# Altium schematic sheet Sheet3.SchDoc(Sheet3)
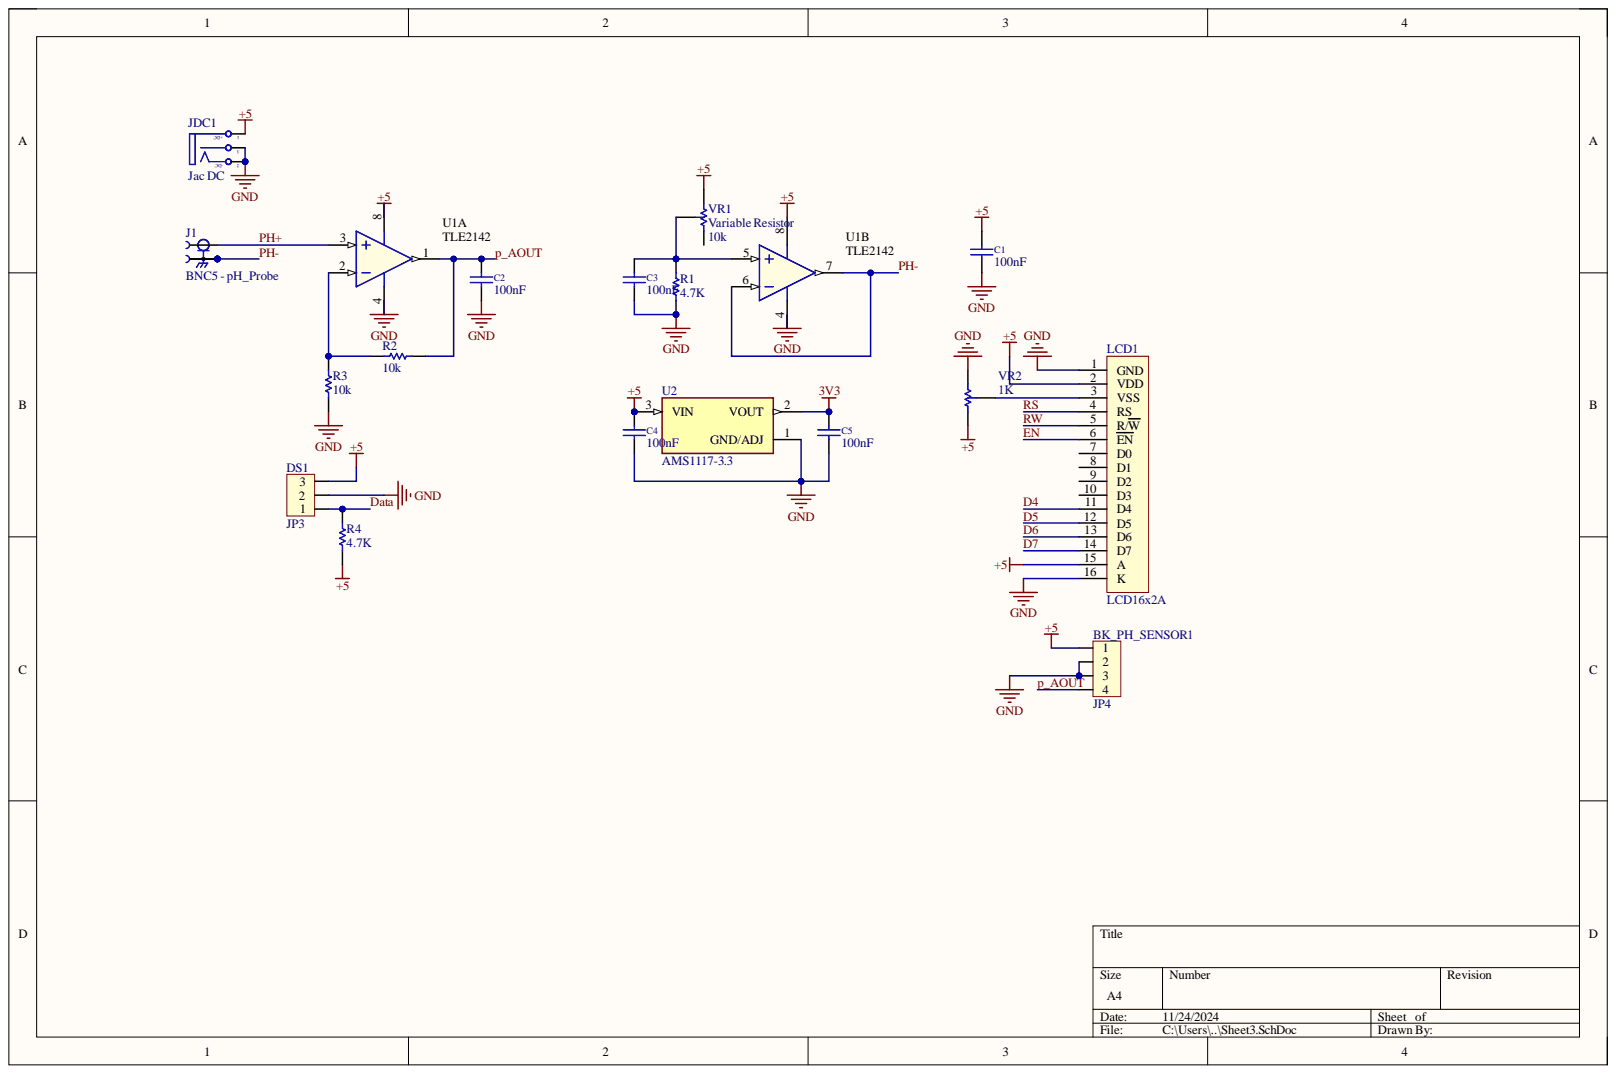
[diagram p0: Sheet3.SchDoc]

## Parts
BK_PH_SENSOR1  JP4  [CON4]
C1  100nF  [C2012-0805]
C2  100nF  [C2012-0805]
C3  100nF  [C2012-0805]
C4  100nF  [C2012-0805]
C5  100nF  [C2012-0805]
DS1  JP3  [CON3]
J1  BNC5 - pH_Probe  [SAMTEC_BNC5-J-P-GN-RA-BH2D]
JDC1  Jac DC  [DC-IN]
LCD1  LCD16x2A  [LCD16X2]
R1  value 4.7K  [R-0805]  (hidden comment: RESISTOR)
R2  value 10k  [R-0805]  (hidden comment: RESISTOR)
R3  value 10k  [R-0805]  (hidden comment: RESISTOR)
R4  value 4.7K  [R-0805]  (hidden comment: RESISTOR)
U1  TLE2142  [SOIC8]  (parts A,B)
U2  AMS1117-3.3  [SOT229P700X180-4N]
VR1  Variable Resistor  value 10k  [VRes-R]
VR2  value 1K  [VRes-C]

## Nets
3V3: C5.2 U2.2
+5: BK_PH_SENSOR1.1 C1.2 C4.2 DS1.3 JDC1.1 LCD1.2(VDD) LCD1.15(A) R4.1 U1.8 U2.3 VR1.1 VR2.1
GND: BK_PH_SENSOR1.2 BK_PH_SENSOR1.3 C1.1 C2.1 C3.1 C4.1 C5.1 DS1.2 JDC1.2 JDC1.3 LCD1.1(GND) LCD1.16(K) R1.1 R3.1 U1.4 U2.1 VR2.3
D4: LCD1.11(D4)
D5: LCD1.12(D5)
D6: LCD1.13(D6)
D7: LCD1.14(D7)
Data: DS1.1 R4.2
EN: LCD1.6(EN)
p_AOUT: BK_PH_SENSOR1.4 C2.2 R2.2 U1.1
PH+: J1.1 U1.3
PH-: J1.S_1 J1.S_2 J1.S_3 J1.S_4 U1.6 U1.7
RS: LCD1.4(RS)
RW: LCD1.5(R/W)
NetC3_2: C3.2 R1.2 U1.5 VR1.2
NetLCD1_3: LCD1.3(VSS) VR2.2
NetR2_1: R2.1 R3.2 U1.2

Not connected: LCD1 7(D0) 8(D1) 9(D2) 10(D3); VR1 3

## Library data of the parts
BK_PH_SENSOR1 = Con4: Connector 4; Component Kind: Standard; Value: Connector 4; Library Name: Kiet.IntLib
C1-C5 = Capacitor: AEMS Code: 2100341; Vendor: Digikey; Volt: 16V; Component Kind: Standard; ComponentLink1Description: Digikey Part Link; ComponentLink2Description: Open Datasheet; Description: Capacitor; PCB3D: SMT_0603; Published: 13-April-2009; Signal Integrity: Guessed Model; Value: 100nF; ComponentLink2URL: H:\LIBRARY\LIBRARY.Datasheet\; Library Name: Kiet.IntLib; Publisher: Hoang Nguyen
DS1 = Con3: Connector 3; Component Kind: Standard; Value: Connector 3; Library Name: Kiet.IntLib
J1 = BNC5-J-P-GN-RA-BH2D: BNC Connector Jack, Female Socket 50Ohm Panel Mount, Through Hole, Right Angle Solder; MF: Samtec; Availability: In Stock; Check_prices: https://www.snapeda.com/parts/BNC5-J-P-GN-RA-BH2D/Samtec%20Inc./view-part/933377/?ref=eda; Component Kind: Standard; Library Name: BNC5-J-P-GN-RA-BH2D.IntLib; MP: BNC5-J-P-GN-RA-BH2D; Package: None; Price: None; Purchase-URL: https://pricing.snapeda.com/search/part/BNC5-J-P-GN-RA-BH2D/?ref=eda; SnapEDA_Link: https://www.snapeda.com/parts/BNC5-J-P-GN-RA-BH2D/Samtec%20Inc./view-part/933377/?ref=snap
JDC1 = DCIN: Jac DC input; Component Kind: Standard; Value: Jac DC; Library Name: Kiet.IntLib
LCD1 = LCD16x2: LCD dipslay 16 char, 2 line; Component Kind: Standard; Value: LCD16x2A; Library Name: Kiet.IntLib
U1 = LM2904: Low-Power Dual Operational Amplifier; Component Kind: Standard; ComponentLink1Description: Manufacturer Link; ComponentLink1URL: http://www.national.com/; ComponentLink2Description: Datasheet; ComponentLink2URL: http://www.national.com/ds.cgi/LM/LM158.pdf; ComponentLink3Description: URL Link1; ComponentLink3URL: http://www.national.com/pf/LM/LM358.html; DatasheetVersion: Sep-1999; LatestRevisionDate: 06-Jun-2005; LatestRevisionNote: Stylized 3D Model Added.; PackageDescription: DIP; 8 Leads; Row Spacing 7.62 mm; Pitch 2.54 mm; PackageReference: N08E; PackageVersion: Sep-1997; Published: 15-Apr-2002; Library Name: Kiet.IntLib; Publisher: Altium Limited
U2 = AMS1117-3.3: Sot223/Pkg 1-Amp 3.3-Volt Low Drop Out Voltage Regulatator; MF: Advanced Monolithic Systems; Availability: Not in stock; Check_prices: https://www.snapeda.com/parts/AMS1117-3.3/Advanced+Monolithic+Systems/view-part/?ref=eda; Component Kind: Standard; Library Name: AMS1117-3.3.IntLib; MP: AMS1117-3.3; Package: SOT-223 Seeed Technology; Price: None; SnapEDA_Link: https://www.snapeda.com/parts/AMS1117-3.3/Advanced+Monolithic+Systems/view-part/?ref=snap
VR2 = Variable Resistor: Component Kind: Standard; Description: Variable Resistor; LatestRevisionDate: 17-Jul-2002; LatestRevisionNote: Re-released for DXP Platform.; PackageReference: AXIAL-0.3; Published: 8-Jun-2000; Library Name: Kiet.IntLib; Publisher: Altium Limited
R1-R4 = RESISTOR: Component Kind: Standard; Description: Resistor; LatestRevisionDate: 17-Jul-2002; LatestRevisionNote: Re-released for DXP Platform.; PackageReference: AXIAL-0.3; Published: 8-Jun-2000; Library Name: Kiet.IntLib; Publisher: Altium Limited
VR1 = Variable Resistor: Component Kind: Standard; Description: Variable Resistor; LatestRevisionDate: 17-Jul-2002; LatestRevisionNote: Re-released for DXP Platform.; PackageReference: AXIAL-0.3; Published: 8-Jun-2000; Library Name: Kiet.IntLib; Publisher: Altium Limited
